# Altium schematic sheet FrontPanel.SchDoc(FrontPanel)
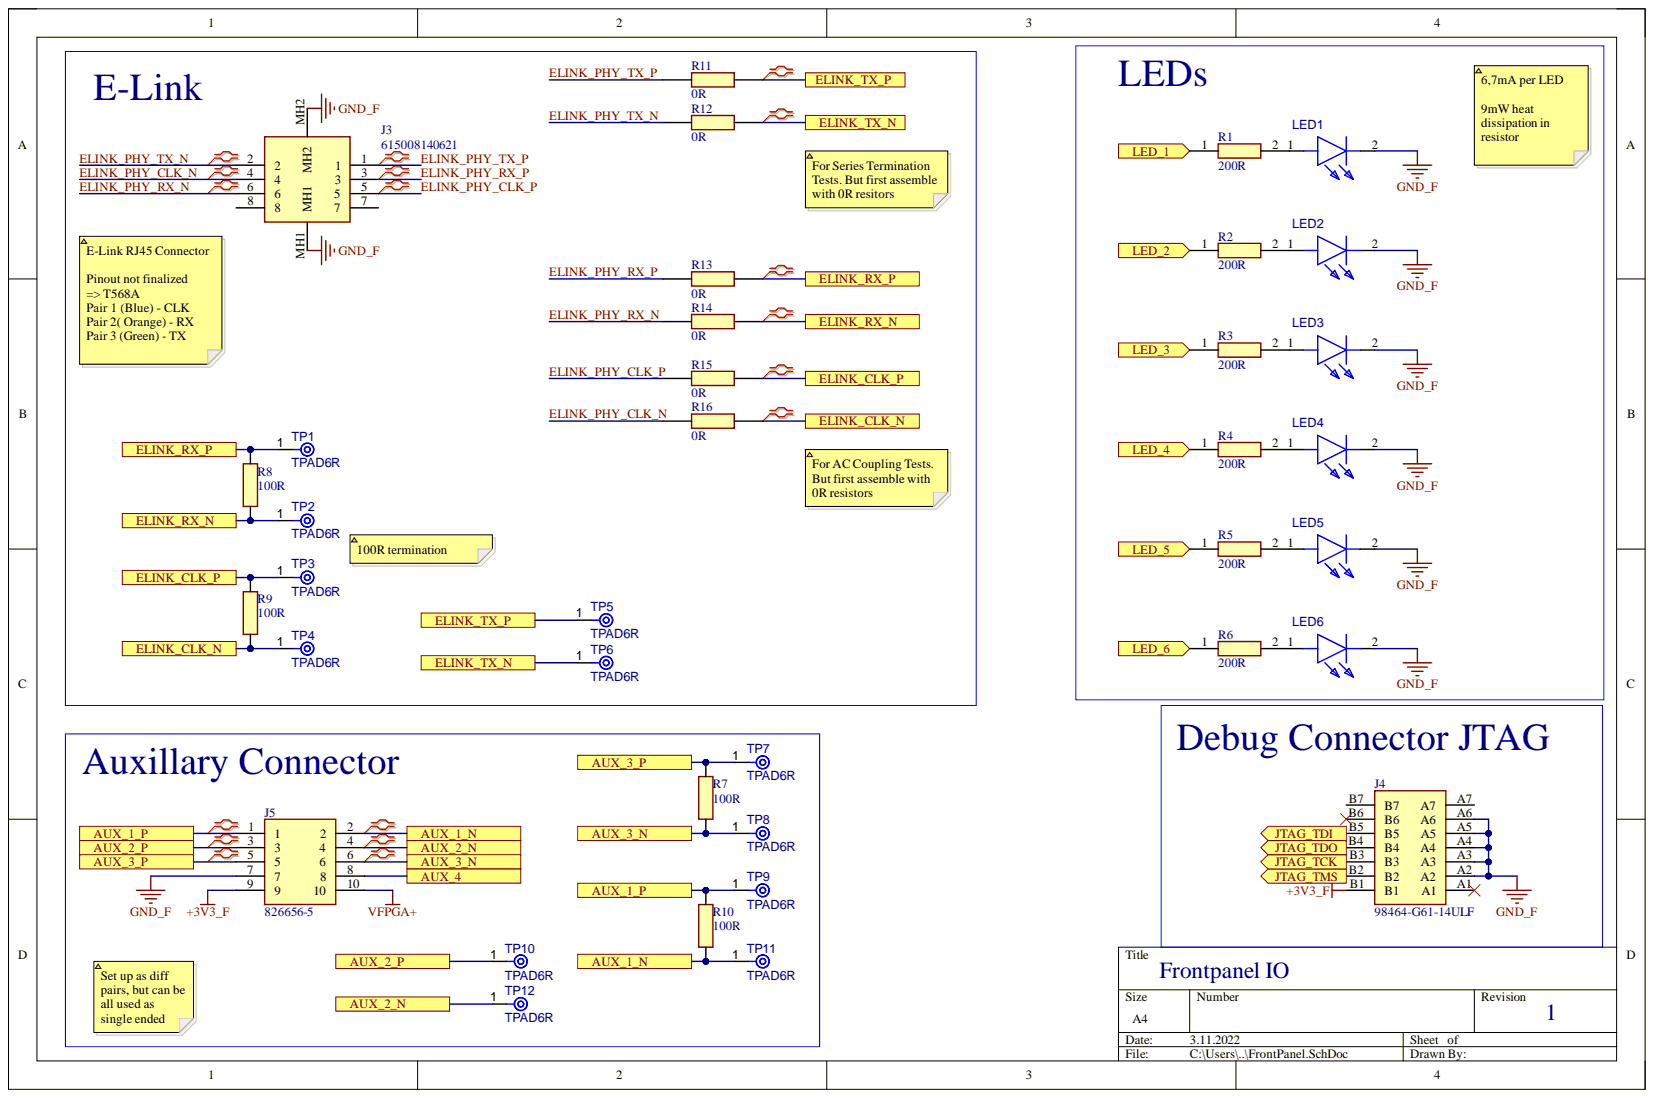
[diagram p0: FrontPanel.SchDoc]

## Parts
J3  615008140621  [615008140621]
J4  98464-G61-14ULF  [98464G6114ULF]
J5  826656-5  [HDRV10W68P254_2X5_1270X500X880P]
LED1  XLUG34D  [XLUG34D]  (value not drawn)
LED2  XLUG34D  [XLUG34D]  (value not drawn)
LED3  XLUG34D  [XLUG34D]  (value not drawn)
LED4  XLUG34D  [XLUG34D]  (value not drawn)
LED5  XLUG34D  [XLUG34D]  (value not drawn)
LED6  XLUG34D  [XLUG34D]  (value not drawn)
R1  200R  [ERJP08_(1206)]
R2  200R  [ERJP08_(1206)]
R3  200R  [ERJP08_(1206)]
R4  200R  [ERJP08_(1206)]
R5  200R  [ERJP08_(1206)]
R6  200R  [ERJP08_(1206)]
R7  100R  [RESC1608X55N]
R8  100R  [RESC1608X55N]
R9  100R  [RESC1608X55N]
R10  100R  [RESC1608X55N]
R11  0R  [RESC2012X65N]
R12  0R  [RESC2012X65N]
R13  0R  [RESC2012X65N]
R14  0R  [RESC2012X65N]
R15  0R  [RESC2012X65N]
R16  0R  [RESC2012X65N]
TP1  TPAD6R  [TP06R]  (no BOM)
TP2  TPAD6R  [TP06R]  (no BOM)
TP3  TPAD6R  [TP06R]  (no BOM)
TP4  TPAD6R  [TP06R]  (no BOM)
TP5  TPAD6R  [TP06R]  (no BOM)
TP6  TPAD6R  [TP06R]  (no BOM)
TP7  TPAD6R  [TP06R]  (no BOM)
TP8  TPAD6R  [TP06R]  (no BOM)
TP9  TPAD6R  [TP06R]  (no BOM)
TP10  TPAD6R  [TP06R]  (no BOM)
TP11  TPAD6R  [TP06R]  (no BOM)
TP12  TPAD6R  [TP06R]  (no BOM)

## Ports
AUX_1_N, AUX_1_P, AUX_2_N, AUX_2_P, AUX_3_N, AUX_3_P, AUX_4, ELINK_CLK_N, ELINK_CLK_P, ELINK_RX_N, ELINK_RX_P, ELINK_TX_N, ELINK_TX_P, JTAG_TCK, JTAG_TDI, JTAG_TDO, JTAG_TMS, LED_1, LED_2, LED_3, LED_4, LED_5, LED_6

## Nets
+3V3_F: J4.B1 J5.9
GND_F: J3.MH1 J3.MH2 J4.A2 J4.A3 J4.A4 J4.A5 J4.A6 J5.7 LED1.2 LED2.2 LED3.2 LED4.2 LED5.2 LED6.2
VFPGA+: J5.10
AUX_1_N: J5.2 R10.1 TP11.1 ; port AUX_1_N
AUX_1_P: J5.1 R10.2 TP9.1 ; port AUX_1_P
AUX_2_N: J5.4 TP12.1 ; port AUX_2_N
AUX_2_P: J5.3 TP10.1 ; port AUX_2_P
AUX_3_N: J5.6 R7.1 TP8.1 ; port AUX_3_N
AUX_3_P: J5.5 R7.2 TP7.1 ; port AUX_3_P
AUX_4: J5.8 ; port AUX_4
ELINK_CLK_N: R9.1 R16.2 TP4.1 ; port ELINK_CLK_N
ELINK_CLK_P: R9.2 R15.2 TP3.1 ; port ELINK_CLK_P
ELINK_PHY_CLK_N: J3.4 R16.1
ELINK_PHY_CLK_P: J3.5 R15.1
ELINK_PHY_RX_N: J3.6 R14.1
ELINK_PHY_RX_P: J3.3 R13.1
ELINK_PHY_TX_N: J3.2 R12.1
ELINK_PHY_TX_P: J3.1 R11.1
ELINK_RX_N: R8.1 R14.2 TP2.1 ; port ELINK_RX_N
ELINK_RX_P: R8.2 R13.2 TP1.1 ; port ELINK_RX_P
ELINK_TX_N: R12.2 TP6.1 ; port ELINK_TX_N
ELINK_TX_P: R11.2 TP5.1 ; port ELINK_TX_P
JTAG_TCK: J4.B3 ; port JTAG_TCK
JTAG_TDI: J4.B5 ; port JTAG_TDI
JTAG_TDO: J4.B4 ; port JTAG_TDO
JTAG_TMS: J4.B2 ; port JTAG_TMS
LED_1: R1.1 ; port LED_1
LED_2: R2.1 ; port LED_2
LED_3: R3.1 ; port LED_3
LED_4: R4.1 ; port LED_4
LED_5: R5.1 ; port LED_5
LED_6: R6.1 ; port LED_6
NetLED1_1: LED1.1 R1.2
NetLED2_1: LED2.1 R2.2
NetLED3_1: LED3.1 R3.2
NetLED4_1: LED4.1 R4.2
NetLED5_1: LED5.1 R5.2
NetLED6_1: LED6.1 R6.2

Not connected: J3 7 8; J4 A1 A7 B6 B7

## Library data of the parts
J3 = 615008140621: Connector; Manufacturer_Name: Wurth Elektronik; Component Kind: Standard; Datasheet Link: http://katalog.we-online.de/em/datasheet/615008140621.pdf; Manufacturer_Part_Number: 615008140621; Mouser Part Number: 710-615008140621; Mouser Price/Stock: https://www.mouser.co.uk/ProductDetail/Wurth-Elektronik/615008140621?qs=rS3zZhy2AQP7iBpY6R1M5A%3D%3D
J4 = 98464-G61-14ULF: Connector; Manufacturer_Name: FCI Electronics; Arrow Part Number: 98464-G61-14ULF; Arrow Price/Stock: https://www.arrow.com/en/products/98464-g61-14ulf/amphenol-fci; Component Kind: Standard; Datasheet Link: https://cdn.amphenol-icc.com/media/wysiwyg/files/drawing/98464.pdf; Height: 6.4mm; Library Name: SamacSys.SchLib; Manufacturer_Part_Number: 98464-G61-14ULF; Mouser Part Number: 649-98464-G61-14ULF; Mouser Price/Stock: https://www.mouser.com/Search/Refine.aspx?Keyword=649-98464-G61-14ULF
J5 = 826656-5: Connector; Manufacturer_Name: TE Connectivity; Arrow Part Number: 826656-5; Arrow Price/Stock: https://www.arrow.com/en/products/826656-5/te-connectivity?region=europe; Component Kind: Standard; Datasheet Link: https://www.te.com/commerce/DocumentDelivery/DDEController?Action=srchrtrv&DocNm=826629&DocType=Customer+Drawing&DocLang=English; Height: 8.8mm; Manufacturer_Part_Number: 826656-5; Mouser Part Number: 571-826656-5; Mouser Price/Stock: https://www.mouser.co.uk/ProductDetail/TE-Connectivity/826656-5?qs=DbJIyW2JsP5STMgZ16SRKA%3D%3D
R1-R6 = ERJ-P08J201V: Resistor; Manufacturer_Name: Panasonic; Component Kind: Standard; Datasheet Link: http://industrial.panasonic.com/cdbs/www-data/pdf/RDO0000/AOA0000C331.pdf; Height: 0.7mm; Library Name: SamacSys.SchLib; Manufacturer_Part_Number: ERJ-P08J201V; Mouser Part Number: 667-ERJ-P08J201V; Mouser Price/Stock: https://www.mouser.com/Search/Refine.aspx?Keyword=667-ERJ-P08J201V
R7-R10 = RNCP0603FTD100R: Resistor; Manufacturer_Name: Stackpole Electronics Inc.; Arrow Part Number: RNCP0603FTD100R; Arrow Price/Stock: https://www.arrow.com/en/products/rncp0603ftd100r/stackpole-electronics; Component Kind: Standard; Datasheet Link: https://www.seielect.com/catalog/sei-rncp.pdf; Height: 0.55mm; Library Name: SamacSys.SchLib; Manufacturer_Part_Number: RNCP0603FTD100R
R11-R16 = RMCF0805ZT0R00: Resistor; Manufacturer_Name: Stackpole Electronics Inc.; Arrow Part Number: RMCF0805ZT0R00; Arrow Price/Stock: https://www.arrow.com/en/products/rmcf0805zt0r00/stackpole-electronics?region=nac; Component Kind: Standard; Datasheet Link: https://www.seielect.com/catalog/sei-rmcf_rmcp.pdf; Height: 0.65mm; Manufacturer_Part_Number: RMCF0805ZT0R00
TP1-TP12 = CMP-00028-00002-2: 0.6mm round; Pins: 1; Library Name: Bergische Universität Wuppertal Fakultät 4 / Physik
LED1-LED6 = XLUG34D: Component Kind: Standard; Library Name: SamacSys.SchLib
